# Altium schematic sheet motherboard-esp32s3.SchDoc
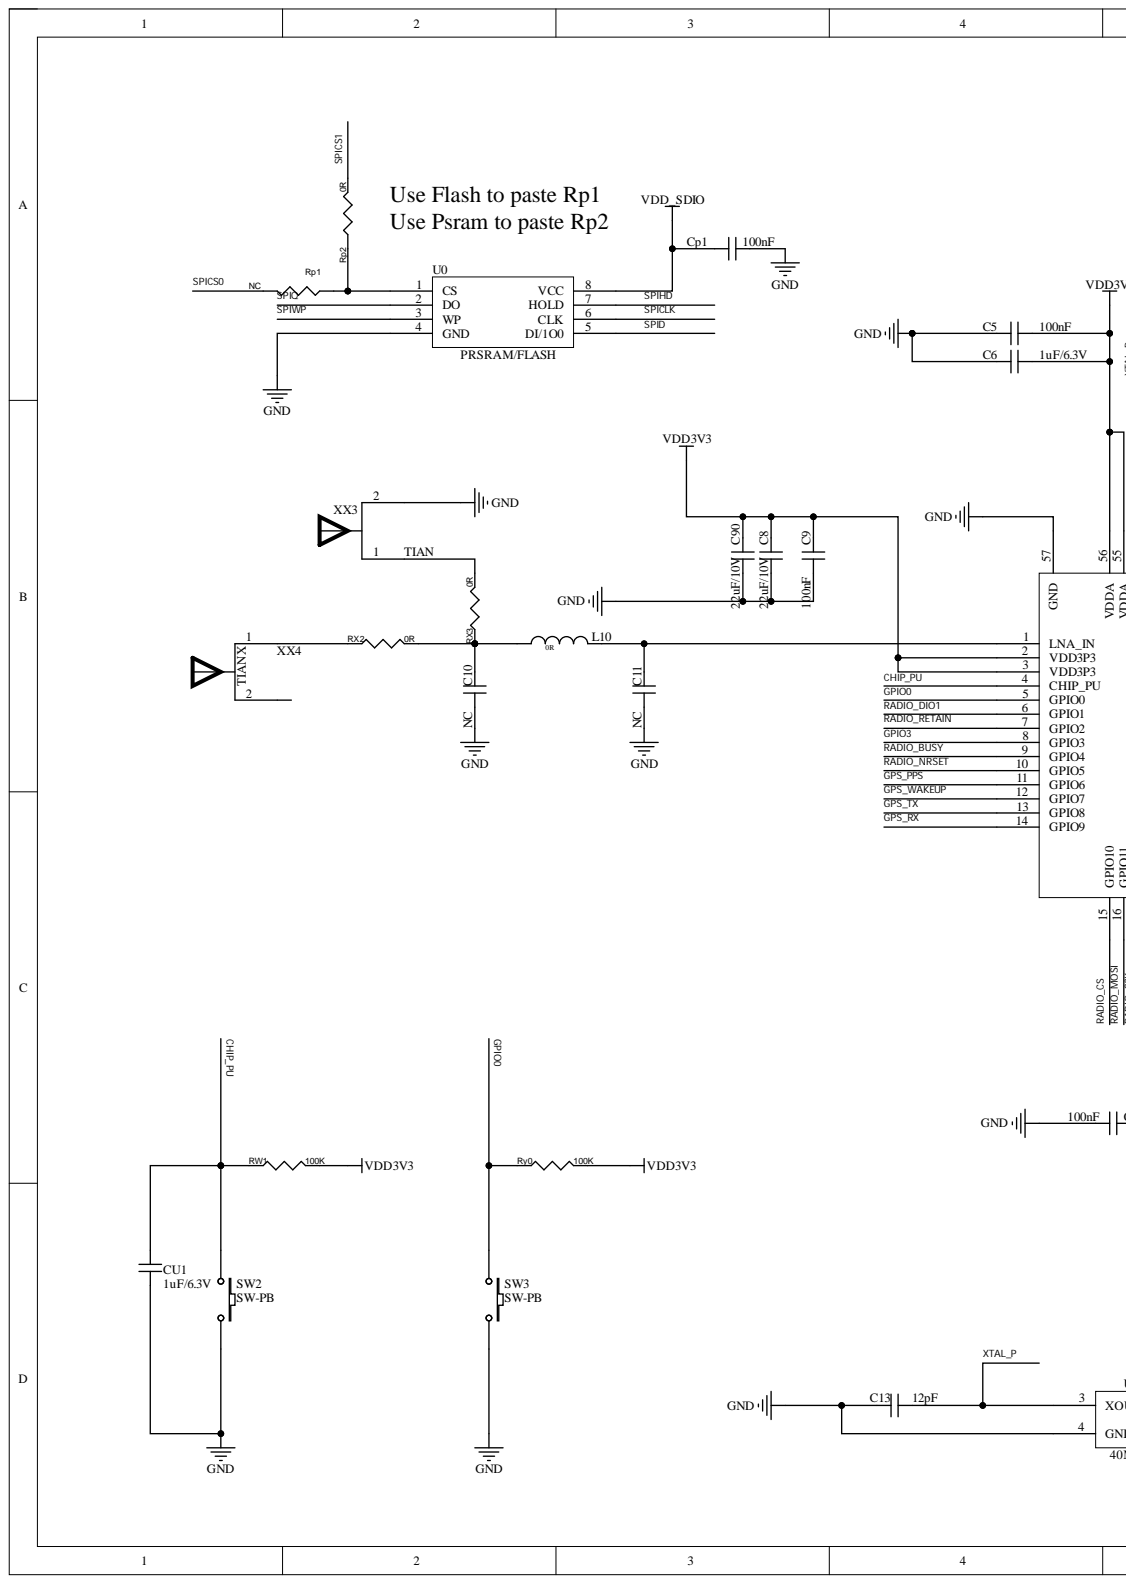
[diagram p0: motherboard-esp32s3.SchDoc, left]
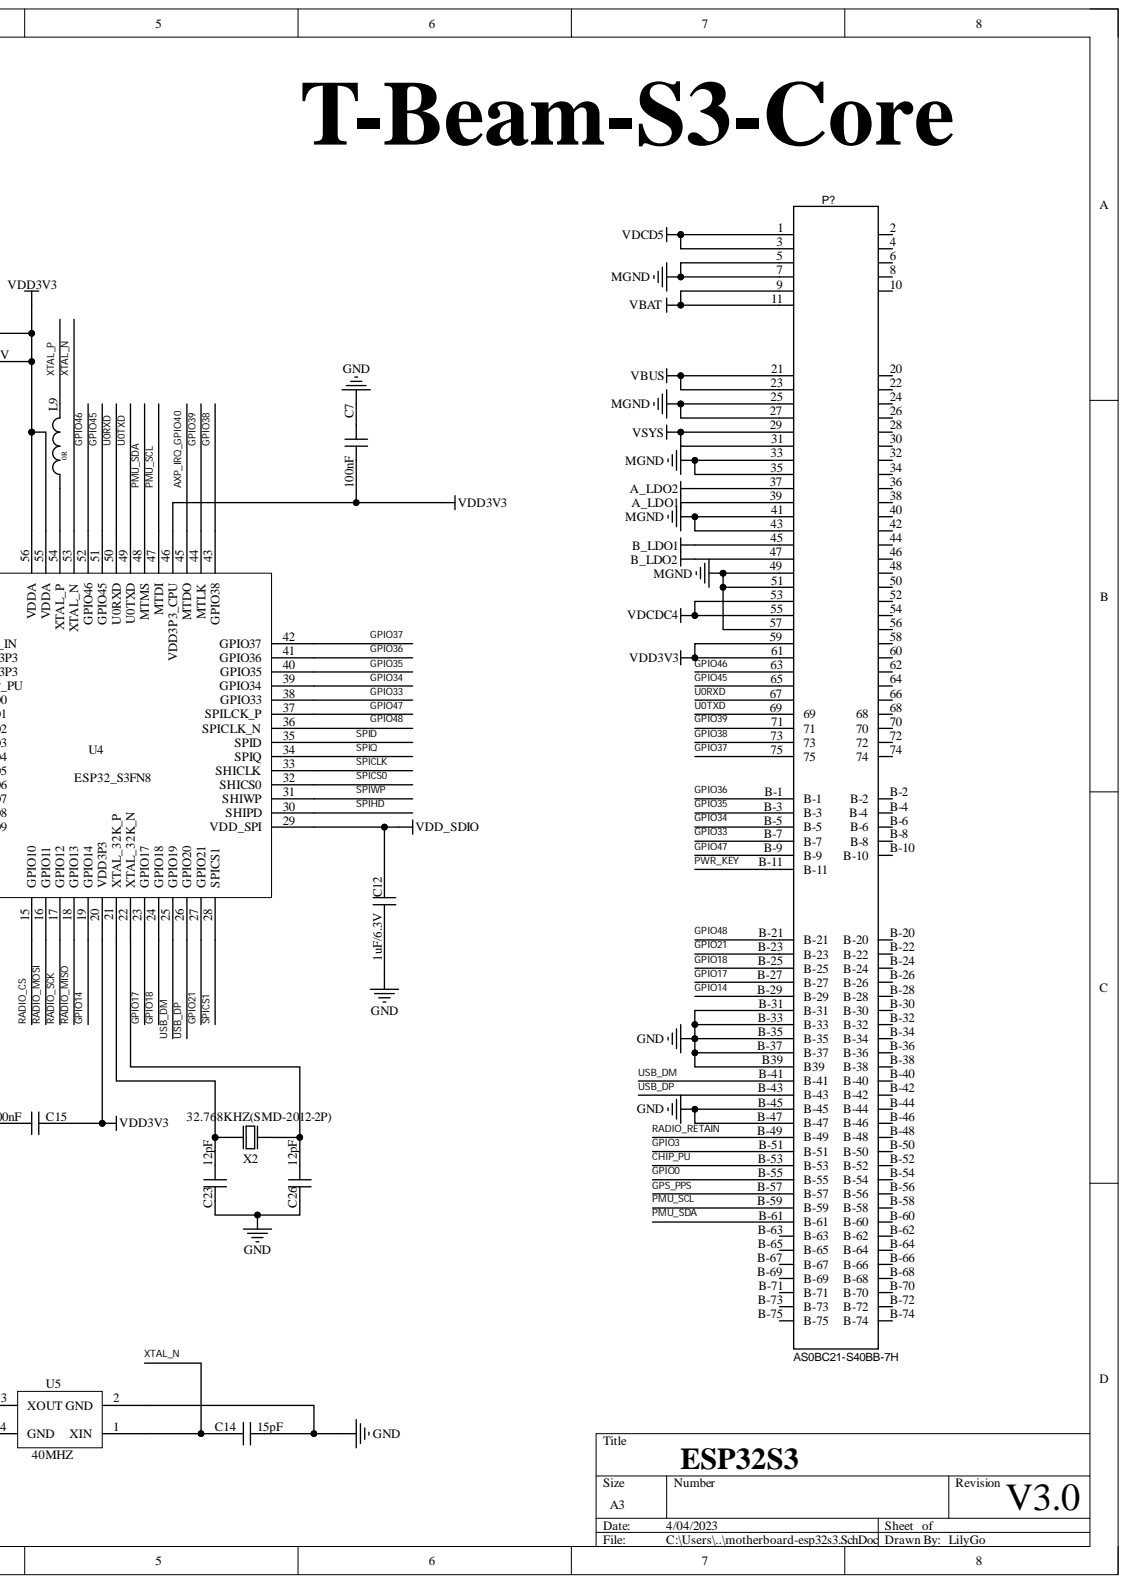
[diagram p1: motherboard-esp32s3.SchDoc, right]

## Parts
C5  100nF  [LC-0402_C]
C6  1uF/6.3V  [LC-0402_C]
C7  100nF  [LC-0402_C]
C8  22uF/10V  [LC-0603_C]
C9  100nF  [LC-0402_C]
C10  NC  [LC-0402_C]
C11  NC  [LC-0402_C]
C12  1uF/6.3V  [LC-0402_C]
C13  12pF  [LC-0402_C]
C14  15pF  [LC-0402_C]
C15  100nF  [LC-0402_C]
C23  12pF  [LC-0402_C]
C26  12pF  [LC-0402_C]
C90  22uF/10V  [LC-0805_C]
Cp1  100nF  [LC-0402_C]
CU1  1uF/6.3V  [LC-0402_C]
L9  0R  [LC-0402_L]
L10  0R  [LC-0402_L]
P?  AS0BC21-S40BB-7H  [CONN-SMD_AS0BC21-S30BB--7Hm]
Rp1  NC  [LC-0402_R]
Rp2  0R  [LC-0402_R]
RW1  100K  [LC-0402_R]
RX2  0R  [LC-0402_R]
RX3  0R  [LC-0402_R]
Ry0  100K  [LC-0402_R]
SW2  SW-PB  [TS-019B]
SW3  SW-PB  [TS-019B]
U0  PRSRAM/FLASH  [SOP-8]
U4  ESP32_S3FN8  [QFN-56]
U5  40MHZ  [CRYSTAL-SMD_4P-L2.0-W1.6-BL-1]
X2  32.768KHZ(SMD-2012-2P)  [FC-12M_L2.0-W1.2]
XX3  TIAN  [ANT_JACK]
XX4  TIANX  [ANT2]

## Nets
A_LDO1: P?.39
A_LDO2: P?.37
B_LDO1: P?.45
B_LDO2: P?.47
GND: C5.2 C6.2 C7.2 C8.2 C9.2 C10.2 C11.2 C12.1 C13.1 C14.2 C15.1 C23.1 C26.1 C90.2 Cp1.2 CU1.1 P?.B39 P?.B-31 P?.B-33 P?.B-35 P?.B-37 P?.B-45 P?.B-47 SW2.2 SW3.2 U0.4(GND) U4.57(GND) U5.2(GND) U5.4(GND) XX3.2
MGND: P?.5 P?.7 P?.25 P?.27 P?.33 P?.35 P?.41 P?.43 P?.49 P?.51 P?.57
VBAT: P?.9 P?.11
VBUS: P?.21 P?.23
VDCD5: P?.1 P?.3
VDCDC4: P?.53 P?.55
VDD3V3: C5.1 C6.1 C7.1 C8.1 C9.1 C15.2 C90.1 P?.59 P?.61 RW1.2 Ry0.2 U4.2(VDD3P3) U4.3(VDD3P3) U4.20(VDD3P3) U4.46(VDD3P3_CPU) U4.55(VDDA) U4.56(VDDA)
VDD_SDIO: C12.2 Cp1.1 U0.8(VCC) U4.29(VDD_SPI)
VSYS: P?.29 P?.31
AXP_IRQ_GPIO40: U4.45(MTDO)
CHIP_PU: CU1.2 P?.B-53 RW1.1 SW2.3 U4.4(CHIP_PU)
GPIO0: P?.B-55 Ry0.1 SW3.3 U4.5(GPIO0)
GPIO3: P?.B-51 U4.8(GPIO3)
GPIO14: P?.B-29 U4.19(GPIO14)
GPIO17: P?.B-27 U4.23(GPIO17)
GPIO18: P?.B-25 U4.24(GPIO18)
GPIO21: P?.B-23 U4.27(GPIO21)
GPIO33: P?.B-7 U4.38(GPIO33)
GPIO34: P?.B-5 U4.39(GPIO34)
GPIO35: P?.B-3 U4.40(GPIO35)
GPIO36: P?.B-1 U4.41(GPIO36)
GPIO37: P?.75 U4.42(GPIO37)
GPIO38: P?.73 U4.43(GPIO38)
GPIO39: P?.71 U4.44(MTLK)
GPIO45: P?.65 U4.51(GPIO45)
GPIO46: P?.63 U4.52(GPIO46)
GPIO47: P?.B-9 U4.37(SPILCK_P)
GPIO48: P?.B-21 U4.36(SPICLK_N)
GPS_PPS: P?.B-57 U4.11(GPIO6)
GPS_RX: U4.14(GPIO9)
GPS_TX: U4.13(GPIO8)
GPS_WAKEUP: U4.12(GPIO7)
PMU_SCL: P?.B-59 U4.47(MTDI)
PMU_SDA: P?.B-61 U4.48(MTMS)
PWR_KEY: P?.B-11
RADIO_BUSY: U4.9(GPIO4)
RADIO_CS: U4.15(GPIO10)
RADIO_DIO1: U4.6(GPIO1)
RADIO_MISO: U4.18(GPIO13)
RADIO_MOSI: U4.16(GPIO11)
RADIO_NRSET: U4.10(GPIO5)
RADIO_RETAIN: P?.B-49 U4.7(GPIO2)
RADIO_SCK: U4.17(GPIO12)
SPICLK: U0.6(CLK) U4.33(SHICLK)
SPICS0: Rp1.2 U4.32(SHICS0)
SPICS1: Rp2.2 U4.28(SPICS1)
SPID: U0.5(DI/1O0) U4.35(SPID)
SPIHD: U0.7(HOLD) U4.30(SHIPD)
SPIQ: U0.2(DO) U4.34(SPIQ)
SPIWP: U0.3(WP) U4.31(SHIWP)
U0RXD: P?.67 U4.50(U0RXD)
U0TXD: P?.69 U4.49(U0TXD)
USB_DM: P?.B-41 U4.25(GPIO19)
USB_DP: P?.B-43 U4.26(GPIO20)
XTAL_N: C14.1 U4.53(XTAL_N) U5.1(XIN)
XTAL_P: C13.2 L9.2 U5.3(XOUT)
NetC10_1: C10.1 L10.1 RX2.2 RX3.1
NetC11_1: C11.1 L10.2 U4.1(LNA_IN)
NetC23_2: C23.2 U4.21(XTAL_32K_P) X2.1
NetC26_2: C26.2 U4.22(XTAL_32K_N) X2.2
NetL9_1: L9.1 U4.54(XTAL_P)
NetP?_2: P?.2
NetP?_4: P?.4
NetP?_6: P?.6
NetP?_8: P?.8
NetP?_10: P?.10
NetP?_20: P?.20
NetP?_22: P?.22
NetP?_24: P?.24
NetP?_26: P?.26
NetP?_28: P?.28
NetP?_30: P?.30
NetP?_32: P?.32
NetP?_34: P?.34
NetP?_36: P?.36
NetP?_38: P?.38
NetP?_40: P?.40
NetP?_42: P?.42
NetP?_44: P?.44
NetP?_46: P?.46
NetP?_48: P?.48
NetP?_50: P?.50
NetP?_52: P?.52
NetP?_54: P?.54
NetP?_56: P?.56
NetP?_58: P?.58
NetP?_60: P?.60
NetP?_62: P?.62
NetP?_64: P?.64
NetP?_66: P?.66
NetP?_68: P?.68
NetP?_70: P?.70
NetP?_72: P?.72
NetP?_74: P?.74
NetP?_B-2: P?.B-2
NetP?_B-4: P?.B-4
NetP?_B-6: P?.B-6
NetP?_B-8: P?.B-8
NetP?_B-10: P?.B-10
NetP?_B-20: P?.B-20
NetP?_B-22: P?.B-22
NetP?_B-24: P?.B-24
NetP?_B-26: P?.B-26
NetP?_B-28: P?.B-28
NetP?_B-30: P?.B-30
NetP?_B-32: P?.B-32
NetP?_B-34: P?.B-34
NetP?_B-36: P?.B-36
NetP?_B-38: P?.B-38
NetP?_B-40: P?.B-40
NetP?_B-42: P?.B-42
NetP?_B-44: P?.B-44
NetP?_B-46: P?.B-46
NetP?_B-48: P?.B-48
NetP?_B-50: P?.B-50
NetP?_B-52: P?.B-52
NetP?_B-54: P?.B-54
NetP?_B-56: P?.B-56
NetP?_B-58: P?.B-58
NetP?_B-60: P?.B-60
NetP?_B-62: P?.B-62
NetP?_B-63: P?.B-63
NetP?_B-64: P?.B-64
NetP?_B-65: P?.B-65
NetP?_B-66: P?.B-66
NetP?_B-67: P?.B-67
NetP?_B-68: P?.B-68
NetP?_B-69: P?.B-69
NetP?_B-70: P?.B-70
NetP?_B-71: P?.B-71
NetP?_B-72: P?.B-72
NetP?_B-73: P?.B-73
NetP?_B-74: P?.B-74
NetP?_B-75: P?.B-75
NetRp1_1: Rp1.1 Rp2.1 U0.1(CS)
NetRX2_1: RX2.1 XX4.1
NetRX3_2: RX3.2 XX3.1

Not connected: XX4 2

## Library data of the parts
C5-C15 C23 C26 C90 Cp1 CU1 = C: Component Kind: Standard; Library Name: 光学蓝牙.SCHLIB
L9 L10 = INDUCTOR: Power Inductor, 4.7uH, 0.7A, 260mR DCR; Manufacturer: Taiyo Yuden; Manufacturer Part Number: CBC2518T4R7M; Part Creator: JG; XMOS Part Number: E-09-0011; Approved: NO; Approx Volume Cost ($): 0.07; DEVICE: L; FORWARD_PCB: 1; LEVEL: STD; Max DC Resistance: 0.26R; Rated Current (Saturation): 0.68A; Rated Current (Temp Rise): 0.92A; Tolerance (%): 20; VALUE: 2.0nH; Component Kind: Standard; Datasheet: http://www.yuden.co.jp/us/product/pdf/ecb2_e.pdf; Digikey Link: http://search.digikey.com/scripts/DkSearch/dksus.dll?lang=en&site=US&keywords=CBC2518T4R7M; Library Name: MySchlib.SchLib; Max Height (mm): 2
P? = AS0BC21-S40BB-7H: Contributor: LCSC; BOM_JLCPCB Part Class: Extended Part; BOM_Manufacturer Part: AS0BC21-S40BB-7H; BOM_Supplier: LCSC; BOM_Supplier Part: C2761526; Component Kind: Standard; Library Name: T-S3.SCHLIB; spicePre: C; spiceSymbolName: AS0BC21-S40BB-7H
Rp1 Rp2 RW1 RX2 RX3 Ry0 = R: Component Kind: Standard; Library Name: 光学蓝牙.SCHLIB
SW2 SW3 = SW-PB: Switch; Component Kind: Standard; LatestRevisionDate: 17-Jul-2002; LatestRevisionNote: Re-released for DXP Platform.; Library Name: Miscellaneous Devices.IntLib; PackageReference: SPST-2; Published: 24-Mar-1999; Publisher: Altium Limited
U0 = GD25LQ128DSIGR: Component Kind: Standard; Library Name: 885阿里云PCB_Project1.SCHLIB
U4 = ESP32_S3: Component Kind: Standard; Library Name: 885阿里云PCB_Project1.SCHLIB
U5 = CRY-D3225: Component Kind: Standard; Library Name: 885阿里云PCB_Project1.SCHLIB
X2 = XTAL_SMD2012_32.768kHz_9pF_MC: XTAL SMD 2012, 32.768kHz, 9pF, ?0ppm; Manufacturer: Micro Crystal; Manufacturer Part Number: CM8V-T1A 32.768KHZ 9PF +/-20PPM TA QC; Part number IPS: 00000007828; Artikkelnr Noca: N.A.; Database Table Name: Crystal_Osc$; Note: XTAL SMD 32.768kHz; Artikkelnr Simpro: N.A.; Component Kind: Standard; Datasheet: http://www.microcrystal.com/images/_PDF/3_Crystal_Ceramic-Package/cm8v-t1a.pdf; Footprint Doc: XTAL_2012; LatestRevisionDate: 2017-01-30; Library Name: Nordic Discrete DB.DbLib; Part Number: XTAL_SMD2012_32.768kHz_9pF_MC; Pin Count: 2; Published: 2016-09-15; Supplier: Allied; Supplier part number: 70417961; Value: 32.768kHz; Publisher: Nordic Semiconductor
XX3 = TIANX: Component Kind: Standard; Library Name: 885阿里云PCB_Project1.SCHLIB
XX4 = TIANX: Component Kind: Standard; Library Name: 885阿里云PCB_Project1.SCHLIB
